# Altium schematic sheet DAC_ES9018.SchDoc
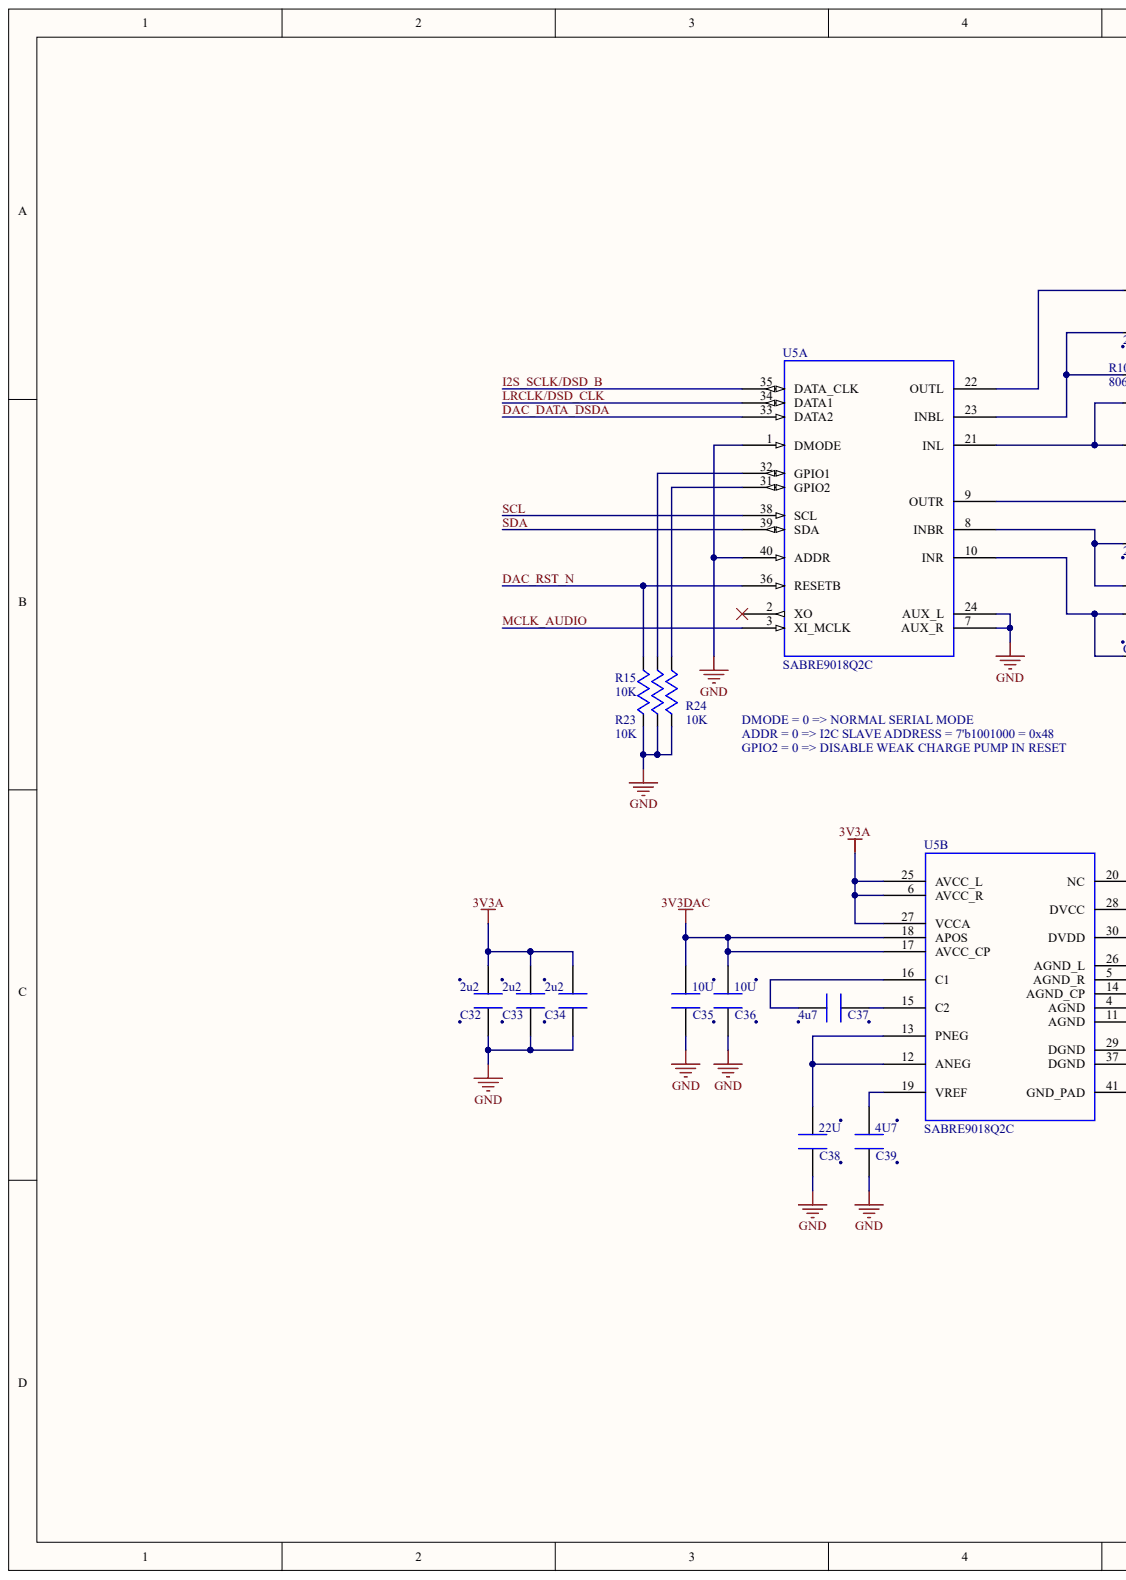
[diagram p0: DAC_ES9018.SchDoc, left]
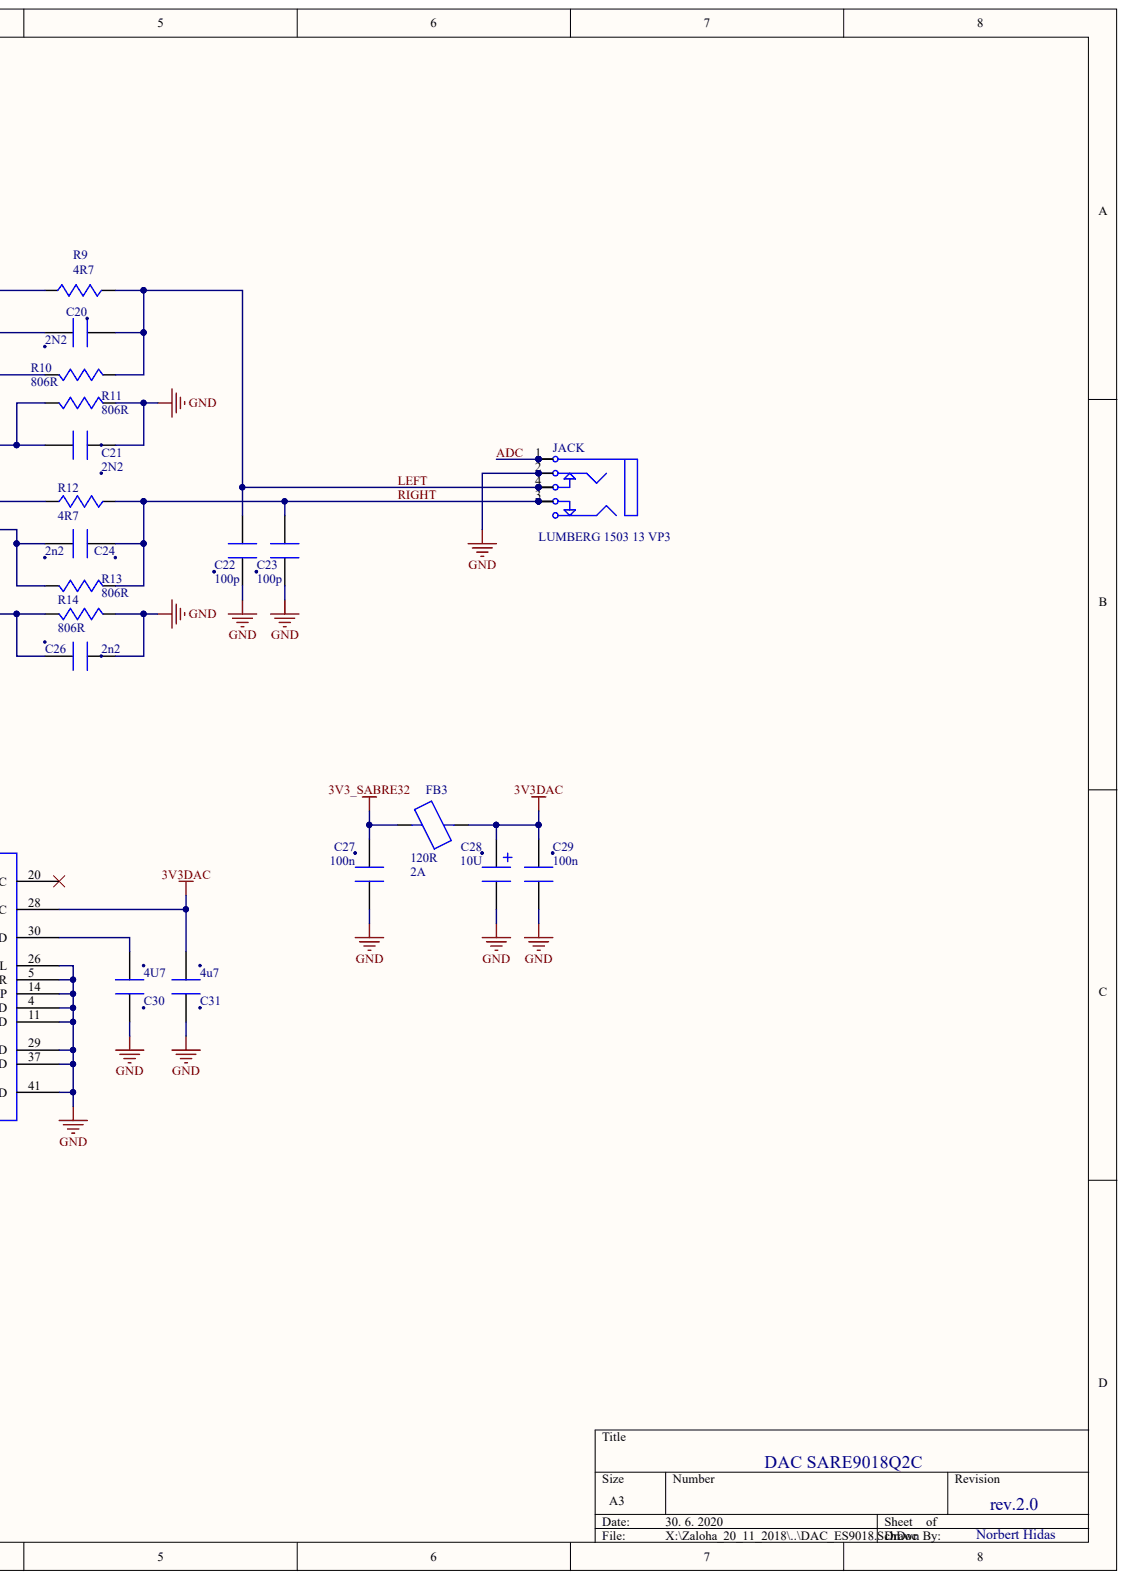
[diagram p1: DAC_ES9018.SchDoc, right]

## Parts
C20  2N2
C21  2N2
C22  100p
C23  100p
C24  2n2
C26  2n2
C27  100n
C28  10U
C29  100n
C30  4U7
C31  4u7
C32  2u2
C33  2u2
C34  2u2
C35  10U
C36  10U
C37  4u7
C38  22U
C39  4U7
FB3  120R  Rated Current: 2A
JACK  LUMBERG 1503 13 VP3
R9  4R7
R10  806R
R11  806R
R12  4R7
R13  806R
R14  806R
R15  10K
R23  10K
R24  10K
U5  SABRE9018Q2C  (parts A,B)

## Nets
3V3_SABRE32: C27.2 FB3.2
3V3A: C32.2 C33.2 C34.2 U5.6(AVCC_R) U5.25(AVCC_L) U5.27(VCCA)
3V3DAC: C28.1 C29.2 C31.2 C35.2 C36.2 FB3.1 U5.17(AVCC_CP) U5.18(APOS) U5.28(DVCC)
GND: C21.1 C22.1 C23.1 C26.2 C27.1 C28.2 C29.1 C30.1 C31.1 C32.1 C33.1 C34.1 C35.1 C36.1 C38.1 C39.1 JACK.2 R11.2 R14.2 R15.2 R23.2 R24.2 U5.1(DMODE) U5.4(AGND) U5.5(AGND_R) U5.7(AUX_R) U5.11(AGND) U5.14(AGND_CP) U5.24(AUX_L) U5.26(AGND_L) U5.29(DGND) U5.37(DGND) U5.40(ADDR) U5.41(GND_PAD)
ADC: JACK.1
DAC_DATA_DSDA: U5.33(DATA2)
DAC_RST_N: R15.1 U5.36(RESETB)
I2S_SCLK/DSD_B: U5.35(DATA_CLK)
LEFT: C20.1 C22.2 JACK.4 R9.1 R10.2
LRCLK/DSD_CLK: U5.34(DATA1)
MCLK_AUDIO: U5.3(XI_MCLK)
RIGHT: C23.2 C24.1 JACK.3 R12.2 R13.2
SCL: U5.38(SCL)
SDA: U5.39(SDA)
NetC20_2: C20.2 R10.1 U5.23(INBL)
NetC21_2: C21.2 R11.1 U5.21(INL)
NetC24_2: C24.2 R13.1 U5.8(INBR)
NetC26_1: C26.1 R14.1 U5.10(INR)
NetC30_2: C30.2 U5.30(DVDD)
NetC37_1: C37.1 U5.15(C2)
NetC37_2: C37.2 U5.16(C1)
NetC38_2: C38.2 U5.12(ANEG) U5.13(PNEG)
NetC39_2: C39.2 U5.19(VREF)
NetR9_2: R9.2 U5.22(OUTL)
NetR12_1: R12.1 U5.9(OUTR)
NetR23_1: R23.1 U5.32(GPIO1)
NetR24_1: R24.1 U5.31(GPIO2)

Not connected: U5 2(XO) 20(NC)

## Library data of the parts
C20 C21 C24 C26 = (no symbol name): Part Number: STD-06-0063; Manufacturer: Murata; Manufacturer Part Number: GRM1885C1H222JA01D; Dielectric: NP0; Rated Voltage: 50V; Tolerance: 5%; Approx Volume Cost ($): 0.01; VALUE: 2N2
C22 C23 = (no symbol name): Part Number: STD-06-0025; Manufacturer: Yageo; Manufacturer Part Number: CC0402JRNPO9BN101; Dielectric: NP0; Rated Voltage: 50V; Tolerance: 5%; Approx Volume Cost ($): 0.003; VALUE: 100P
C27 C29 = (no symbol name): Part Number: STD-06-0048; Manufacturer: Yageo; Manufacturer Part Number: CC0402KRX7R7BB104; Dielectric: X7R; Rated Voltage: 16V; Tolerance: 10%; Approx Volume Cost ($): 0.003; VALUE: 100N
C28 = (no symbol name): Part Number: GEN-03-0006; Manufacturer: AVX; Manufacturer Part Number: TPSA106K010R0900; Dielectric: TANT; Rated Voltage: 10V; Tolerance: 10%; Approx Volume Cost ($): 0.17; VALUE: 10U
C30 C31 C39 = (no symbol name): Part Number: GEN-03-0020; Manufacturer: Murata; Manufacturer Part Number: GRM155R60J475ME47D; Dielectric: X5R; Rated Voltage: 6.3V; Tolerance: 20%; Approx Volume Cost ($): 0.02; VALUE: 4U7
C32-C34 = (no symbol name): Part Number: STD-06-0077; Manufacturer: Murata; Manufacturer Part Number: GRM188R61A225KE34D; Dielectric: X5R; Rated Voltage: 10V; Tolerance: 10%; Approx Volume Cost ($): 0.024; VALUE: 2U2
C35 C36 = (no symbol name): Part Number: GEN-03-0022; Manufacturer: AVX; Manufacturer Part Number: 06036D106MAT2A; Dielectric: X5R; Rated Voltage: 6.3V; Tolerance: 20%; Approx Volume Cost ($): 0.03; VALUE: 10U
C37 = (no symbol name): Part Number: STD-06-0078; Manufacturer: Murata; Manufacturer Part Number: GRM188R60J475KE19D; Dielectric: X5R; Rated Voltage: 6.3V; Tolerance: 10%; Approx Volume Cost ($): 0.025; VALUE: 4U7
C38 = (no symbol name): Part Number: GEN-03-0003; Manufacturer: TDK; Manufacturer Part Number: C1608X5R0J226M080AC; Dielectric: X5R; Rated Voltage: 6.3V; Tolerance: 20%; Approx Volume Cost ($): 0.08; VALUE: 22U
FB3 = (no symbol name): Part Number: GEN-04-0004; Manufacturer: Murata; Manufacturer Part Number: BLM18PG121SN1; Max DCR: 0.05R; Approx Volume Cost ($): 0.022; VALUE: 120R
R9 R12 = (no symbol name): Part Number: STD-02-0010; Manufacturer: Yageo; Manufacturer Part Number: RC0603JR-074R7L; Tolerance: 5%; Max Voltage: 50V; Max Power: 100mW; Temperature Coefficient: ±200ppm/°C; Approx Volume Cost ($): 0.002; VALUE: 4R7
R10 R11 R13 R14 = (no symbol name): Part Number: STD-03-0172; Manufacturer: Yageo; Manufacturer Part Number: RC0603FR-07806RL; Tolerance: 1%; Max Voltage: 50V; Max Power: 100mW; Temperature Coefficient: ±100ppm/°C; Approx Volume Cost ($): 0.002; VALUE: 806R
R15 R23 R24 = (no symbol name): Part Number: STD-01-0080; Manufacturer: Yageo; Manufacturer Part Number: RC0402FR-0710KL; Tolerance: 1%; Max Voltage: 50V; Max Power: 63mW; Temperature Coefficient: ±100ppm/°C; Approx Volume Cost ($): 0.002; VALUE: 10K
U5 = (no symbol name): Part Number: GEN-06-0004; Manufacturer: ESS Technology; Approx Volume Cost ($): 5; VALUE: SABRE9018Q2C; Manufacturer Part Number: SABRE9018Q2C
JACK = (no symbol name): Published: 5-Jan-2004; LatestRevisionDate: 17-Dec-2003; LatestRevisionNote: PCB Footprint replaced.; DatasheetDocument: 07-Mar-2000; ComponentLink1Description: Manufacturer Link; ComponentLink1URL: http://domino.kycon.com/internet/Products.html; Publisher: Altium Limited
